# Altium schematic sheet SD Card.SchDoc("SD Card")
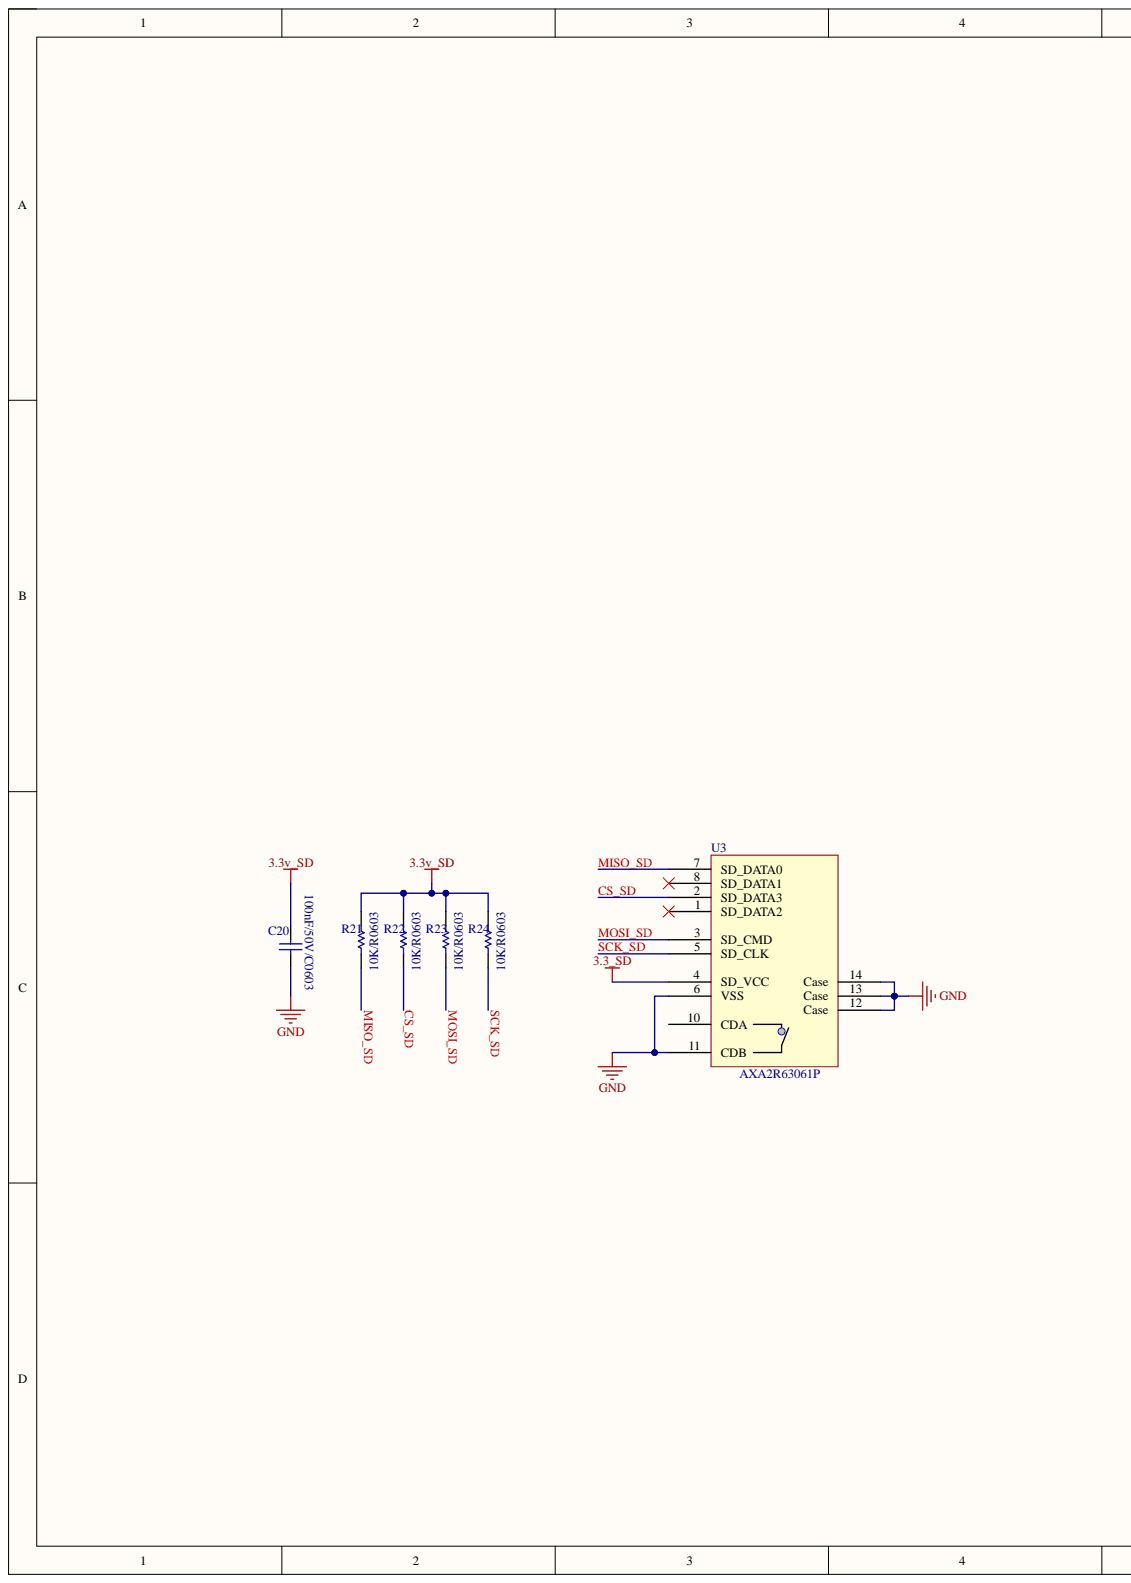
[diagram p0: SD Card.SchDoc, left]
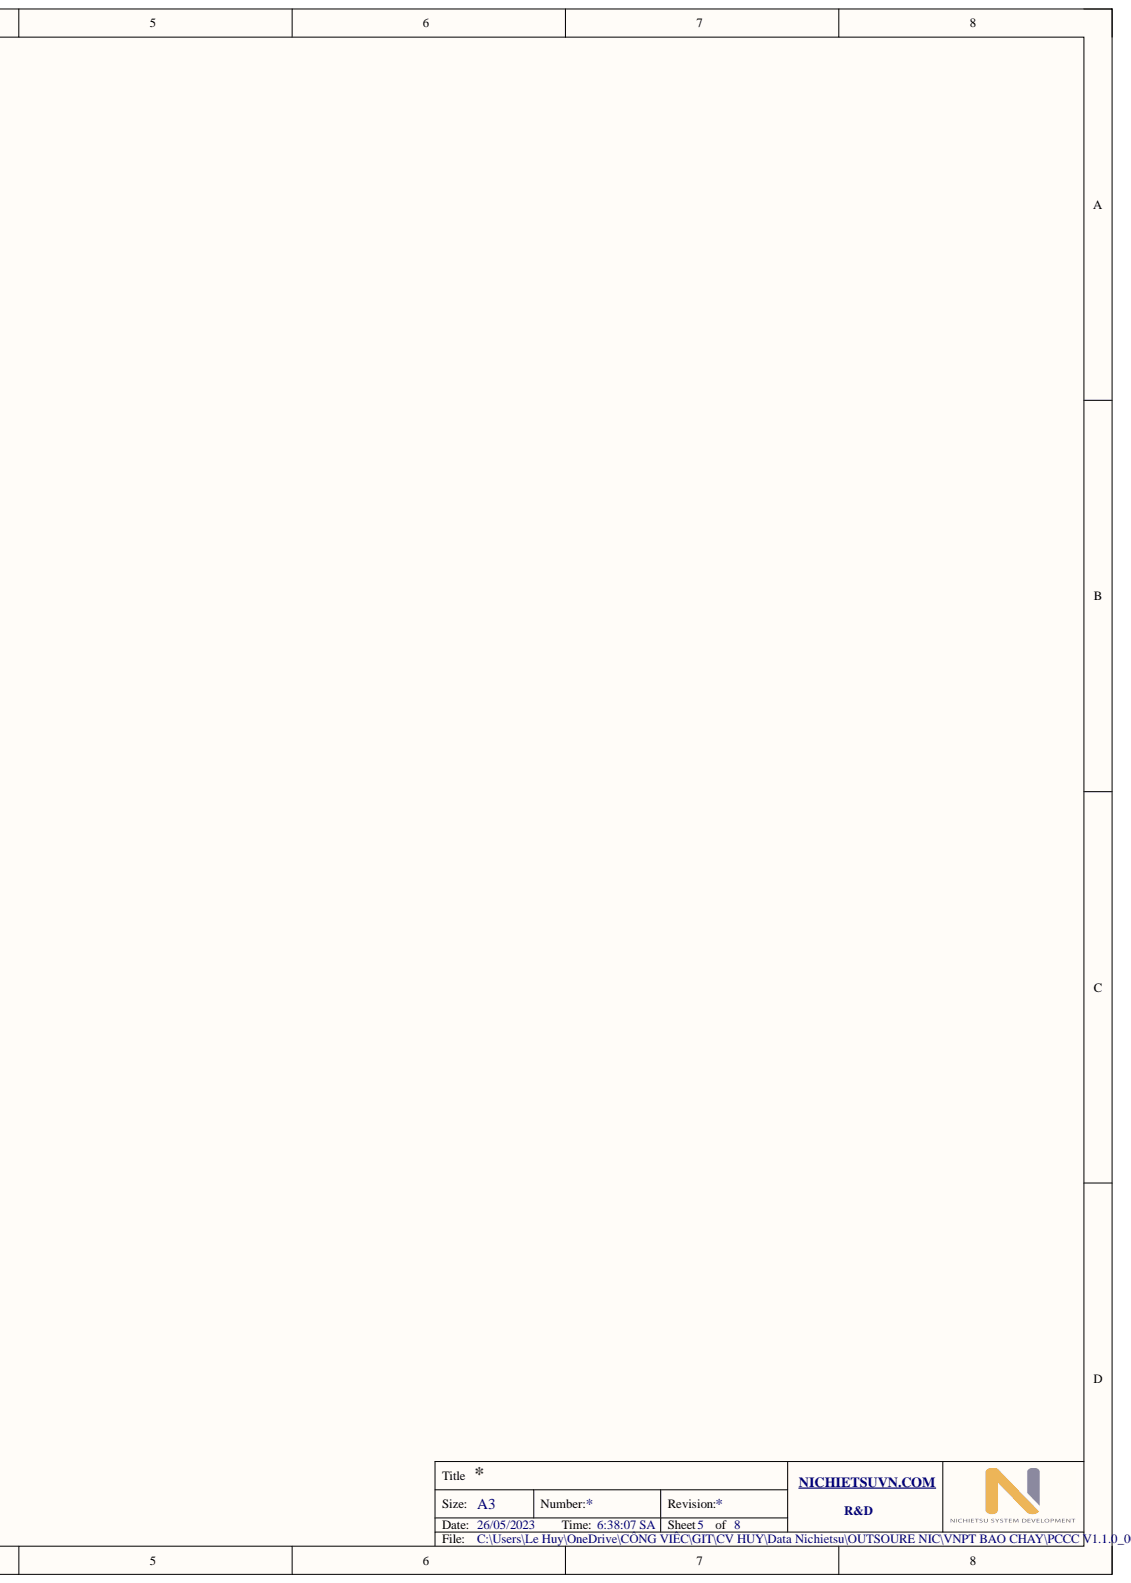
[diagram p1: SD Card.SchDoc, right]

## Parts
C20  100nF/50V/C0603  [C0603]
R21  10K/R0603  [R0603]
R22  10K/R0603  [R0603]
R23  10K/R0603  [R0603]
R24  10K/R0603  [R0603]
U3  AXA2R63061P  [mini SD card - T-flash]

## Nets
3.3_SD: U3.4(SD_VCC)
3.3v_SD: C20.2 R21.2 R22.2 R23.2 R24.2
GND: C20.1 U3.6(VSS) U3.11(CDB) U3.12(Case) U3.13(Case) U3.14(Case)
CS_SD: U3.2(SD_DATA3)
MISO_SD: R21.1 U3.7(SD_DATA0)
MOSI_SD: R23.1 U3.3(SD_CMD)
SCK_SD: R24.1 U3.5(SD_CLK)

Not connected: R22 1; U3 1(SD_DATA2) 8(SD_DATA1) 10(CDA)

## Library data of the parts
C20 = 0.1uF/50V/C0603/10%: CAP CER 0.1UF 50V 10% X7R 0603; Manufacturer: Murata Electronics North America; Manufacturer Part Number: GRM188R71H104KA93D; Capacitance: 0.1µF; Applications: General Purpose; Category: Capacitors; Component Kind: Standard; ComponentLink1Description: http://www.murata.com/~/media/webrenewal/support/library/catalog/products/capacitor/mlcc/c02e.ashx; ComponentLink1URL: http://www.murata.com/~/media/webrenewal/support/library/catalog/products/capacitor/mlcc/c02e.ashx; Features: -; Height - Seated (Max): -; Lead Spacing: -; Lead Style: -; Mounting Type: Surface Mount, MLCC; Operating Temperature: -55°C ~ 125°C; Package / Case: 0603 (1608 Metric); Packaging: Tape & Reel (TR); Ratings: -; RoHS: RoHS Compliant; Size / Dimension: 0.063" L x 0.031" W (1.60mm x 0.80mm); Supplier 1: Digi-Key; Supplier Part Number 1: 490-1519-1-ND; Temperature Coefficient: X7R; Thickness (Max): 0.035" (0.90mm); Tolerance: ±10%; Voltage - Rated: 50V; Library Name: Manh_Capacitor.SchLib
R21-R24 = 10K/R0603/1%: RES SMD 10K OHM 1% 1/10W 0603; Manufacturer: Yageo; Manufacturer Part Number: RC0603FR-0710KL; Power (Watts): 0.1W, 1/10W; Temperature Coefficient: ±100ppm/°C; Category: Resistors; Component Kind: Standard; ComponentLink1Description: http://www.yageo.com/NewPortal/yageodocoutput?fileName=/pdf/R-Chip/PYu-RC_Group_51_RoHS_L_3.pdf; ComponentLink1URL: http://www.yageo.com/NewPortal/yageodocoutput?fileName=/pdf/R-Chip/PYu-RC_Group_51_RoHS_L_3.pdf; Composition: Thick Film; Features: Moisture Resistant; Height: 0.022" (0.55mm); Number of Terminations: 2; Operating Temperature: -55°C ~ 155°C; Package / Case: 0603 (1608 Metric); Packaging: Tape & Reel (TR); Pricing 1: 5000=0.00167, 10000=0.00145, 25000=0.00128, 50000=0.00117, 125000=0.00115 (USD); Resistance (Ohms): 10k; RoHS: RoHS Compliant; Size / Dimension: 0.063" L x 0.031" W (1.60mm x 0.80mm); Stock 1: 11440000; Supplier 1: Digi-Key; Supplier Device Package: 0603; Supplier Part Number 1: 311-10.0KHRTR-ND; Tolerance: ±1%; Library Name: Manh_Resistor.SchLib
U3 = MICRO SD CARD P-P R/A SMD: CONN SD CARD PUSH-PUSH R/A SMD; Manufacturer: Panasonic Electric Works; Card Type: Secure Digital - SD; Connector Type: Connector and Ejector; Insertion, Removal Method: Push In, Push Out; Number of Positions: 12 (9 + 3); Category: Connectors, Interconnects; Component Kind: Standard; ComponentLink1Description: http://media.digikey.com/pdf/Data%20Sheets/Panasonic%20Electric%20Works%20PDFs/AXA2R%20Rev.Oct1,2009.pdf; ComponentLink1URL: http://media.digikey.com/pdf/Data%20Sheets/Panasonic%20Electric%20Works%20PDFs/AXA2R%20Rev.Oct1,2009.pdf; Contact Finish: Gold; Contact Finish Thickness: Flash; Ejector Side: -; Features: Board Guide, Switch; Height Above Board: 0.134" (3.40mm); Manufacturer Part Number: AXA2R63061P; Mounting Feature: Normal, Standard - Top; Mounting Type: Surface Mount, Right Angle; Packaging: Tape & Reel (TR); Part Status: Obsolete; Pricing 1: 350=0 (USD); RoHS: RoHS Compliant; Stock 1: 0; Supplier 1: Digi-Key; Library Name: Manh_Miscellaneous.SchLib
